# Altium schematic sheet IO_section.SchDoc
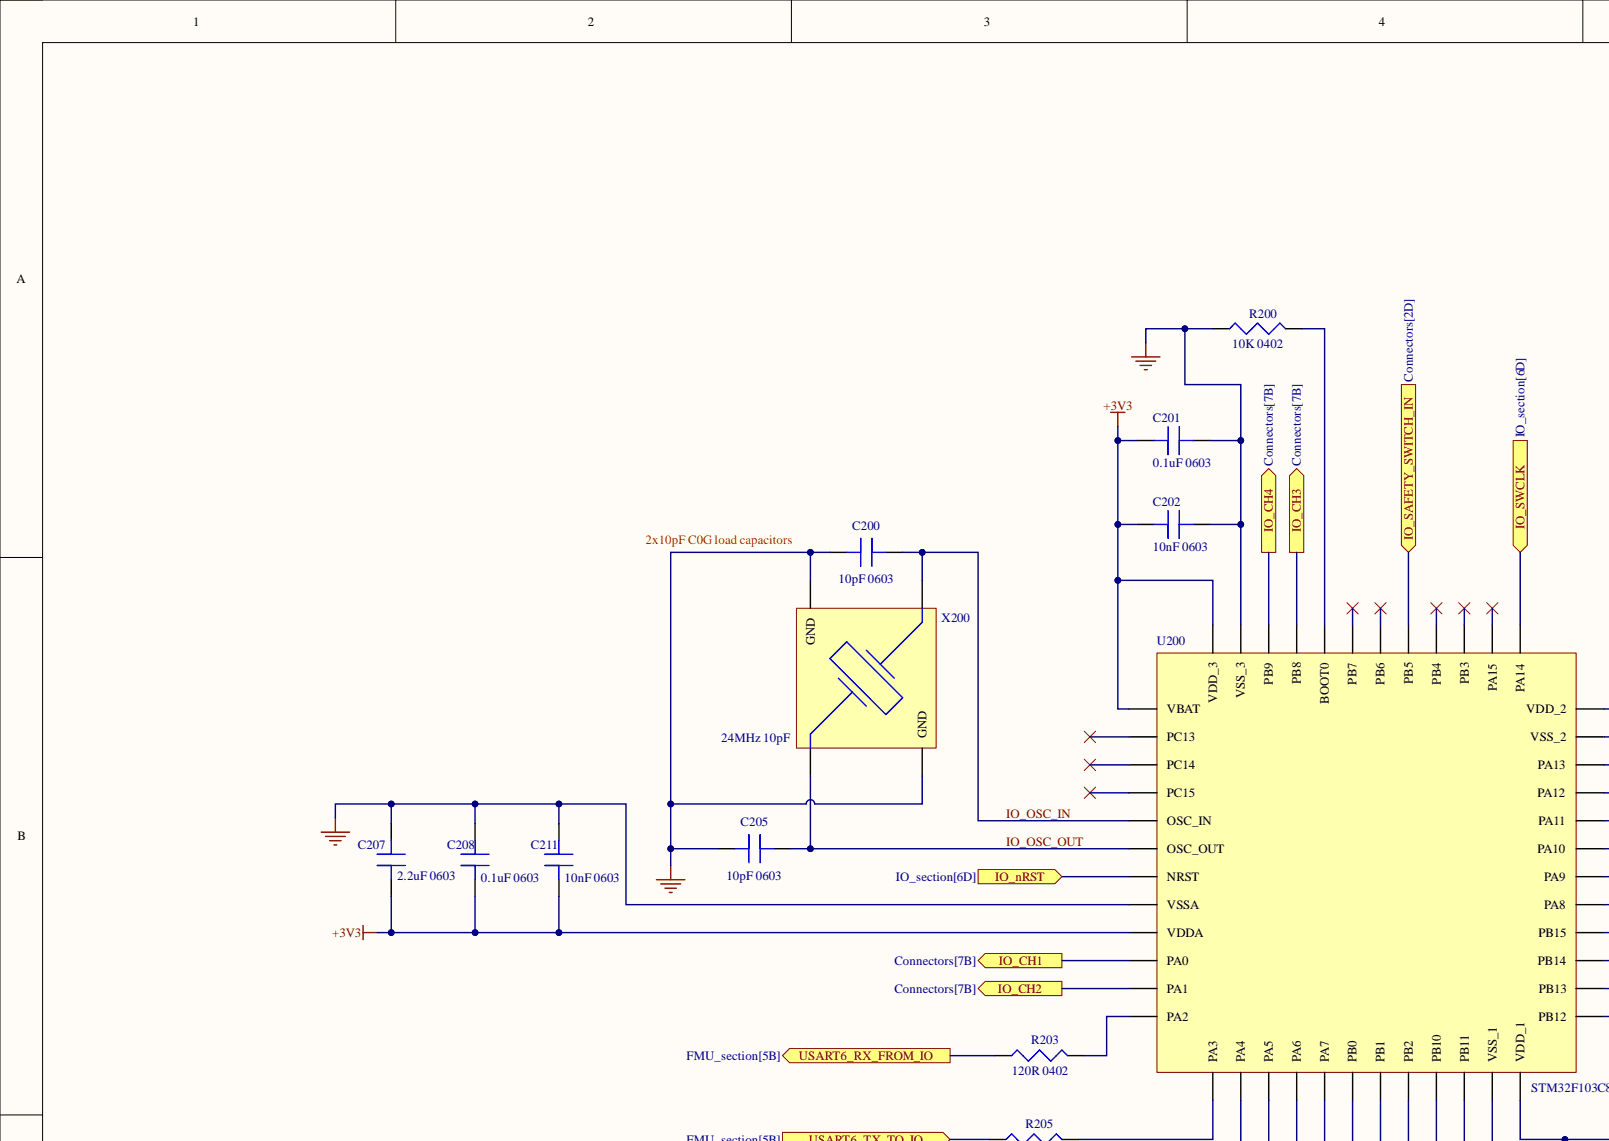
[diagram p0: IO_section.SchDoc, top left]
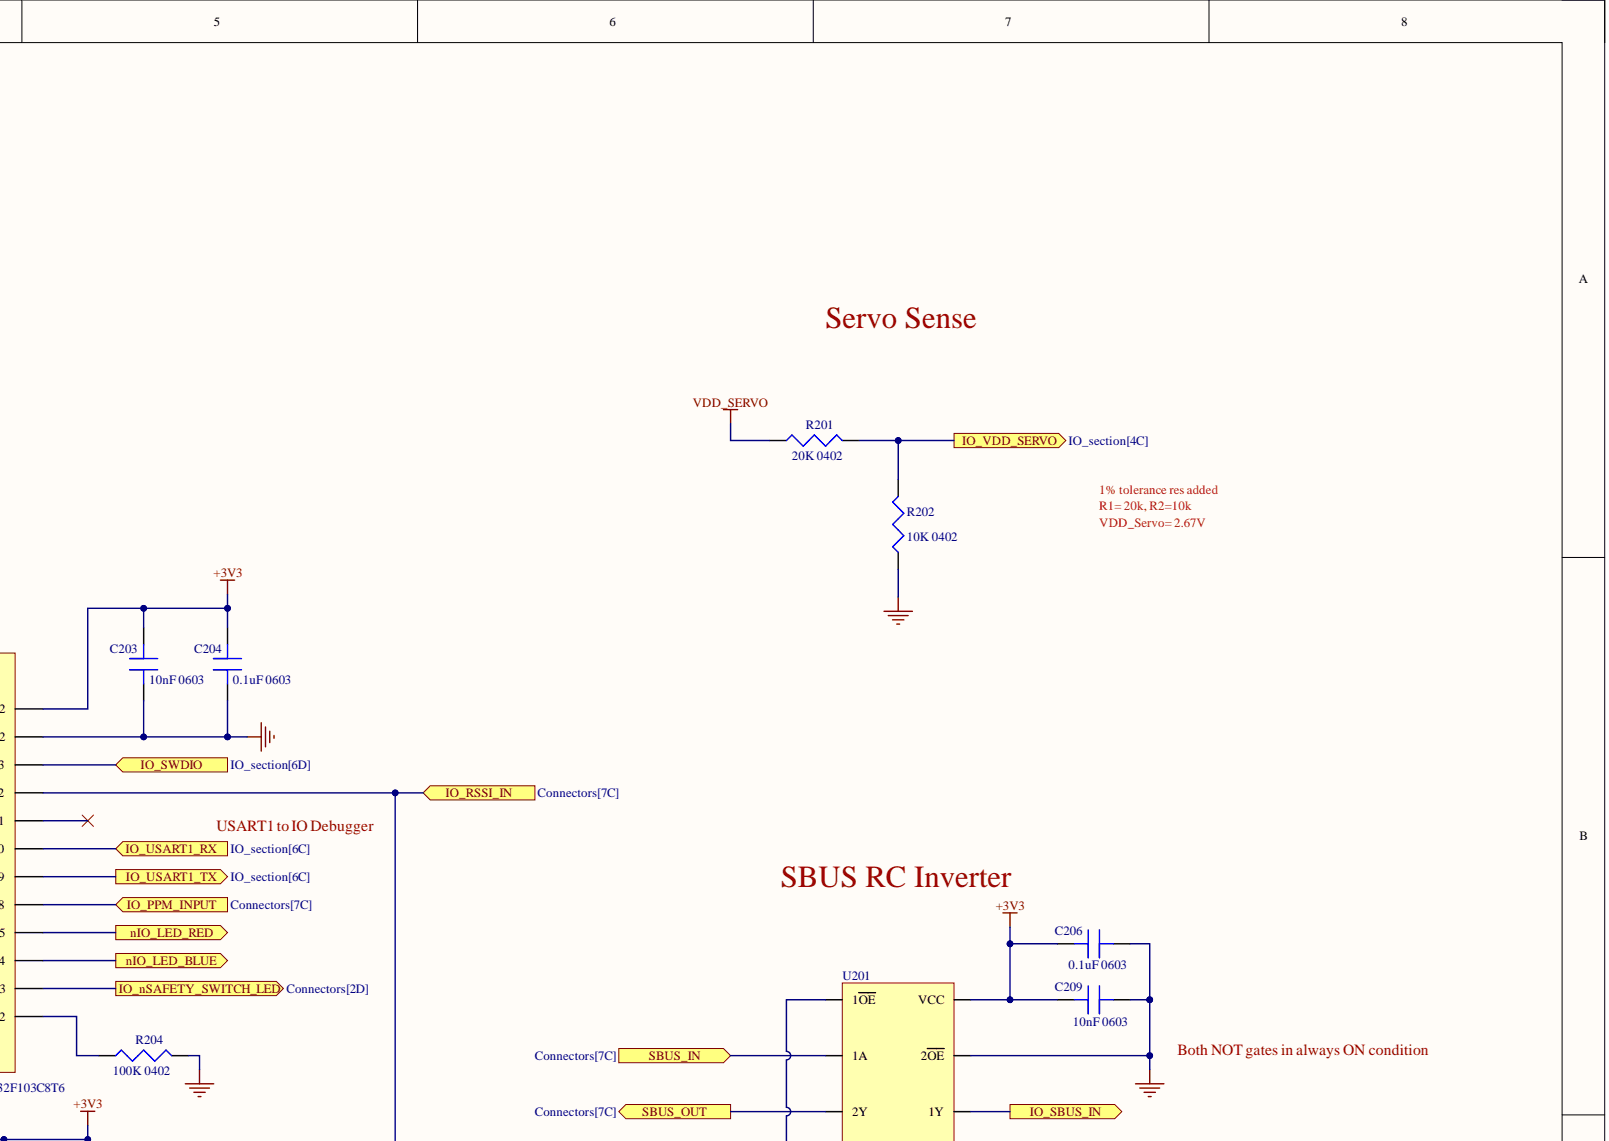
[diagram p1: IO_section.SchDoc, top right]
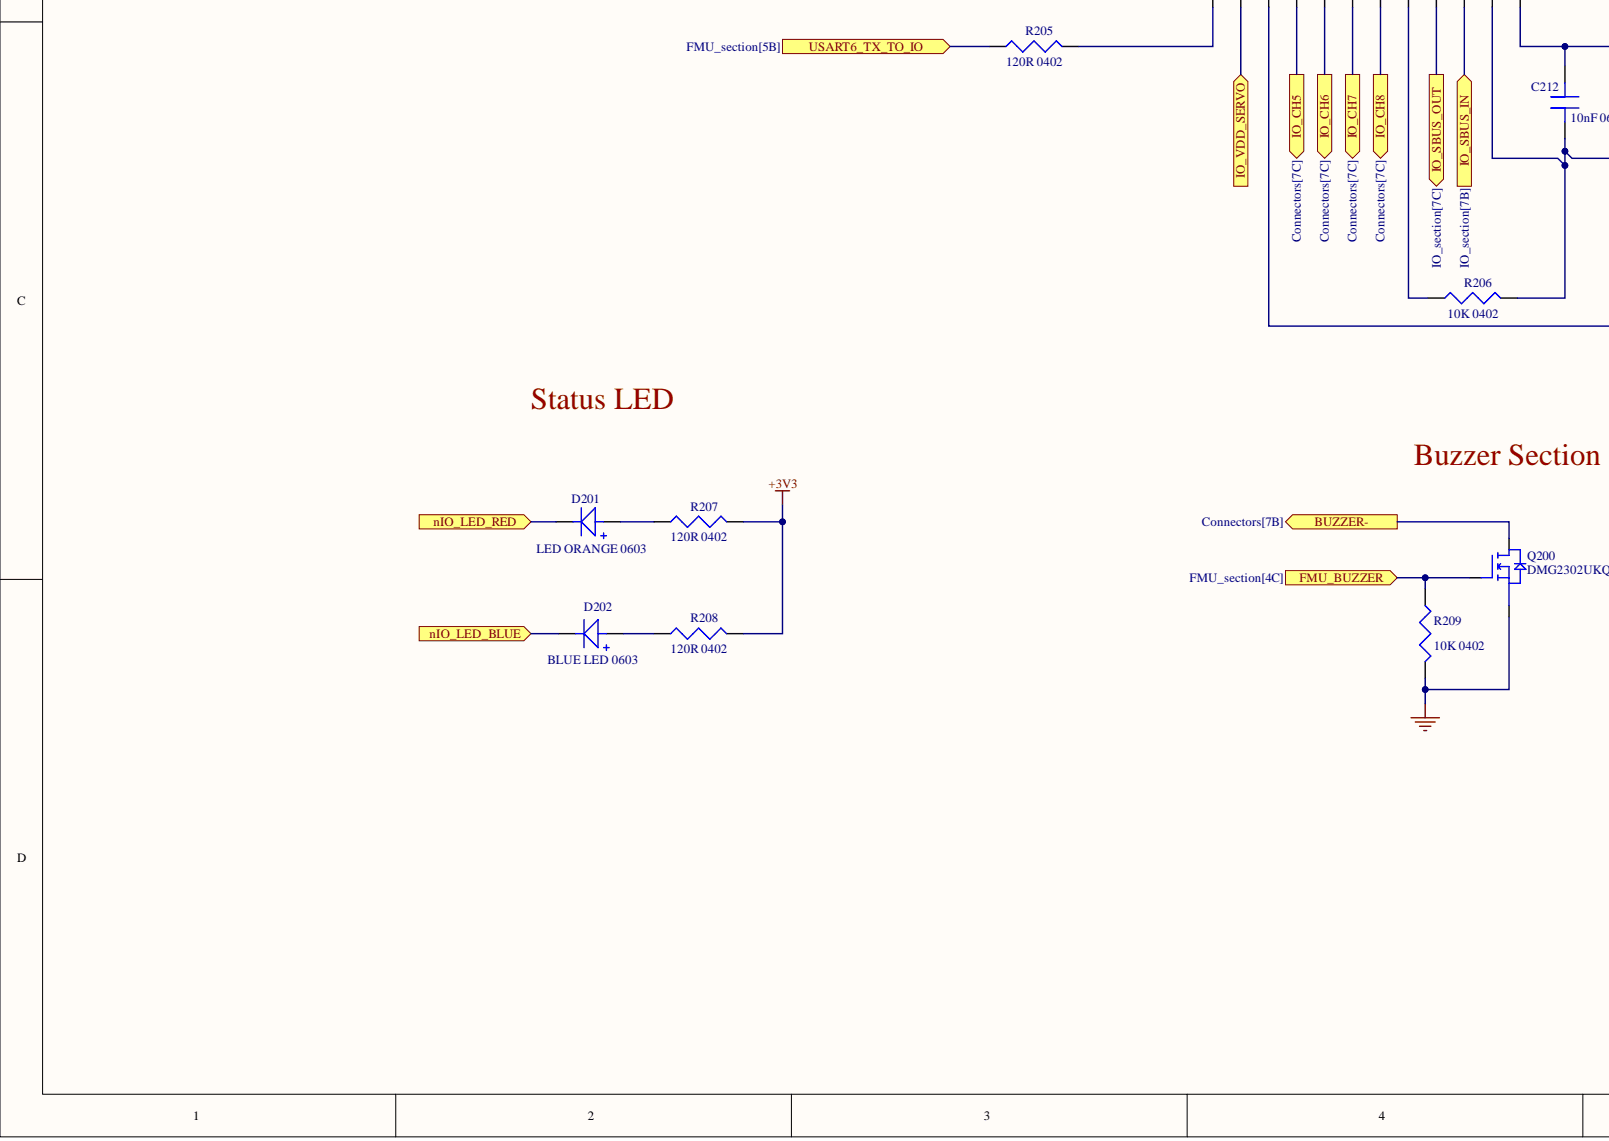
[diagram p2: IO_section.SchDoc, bottom left]
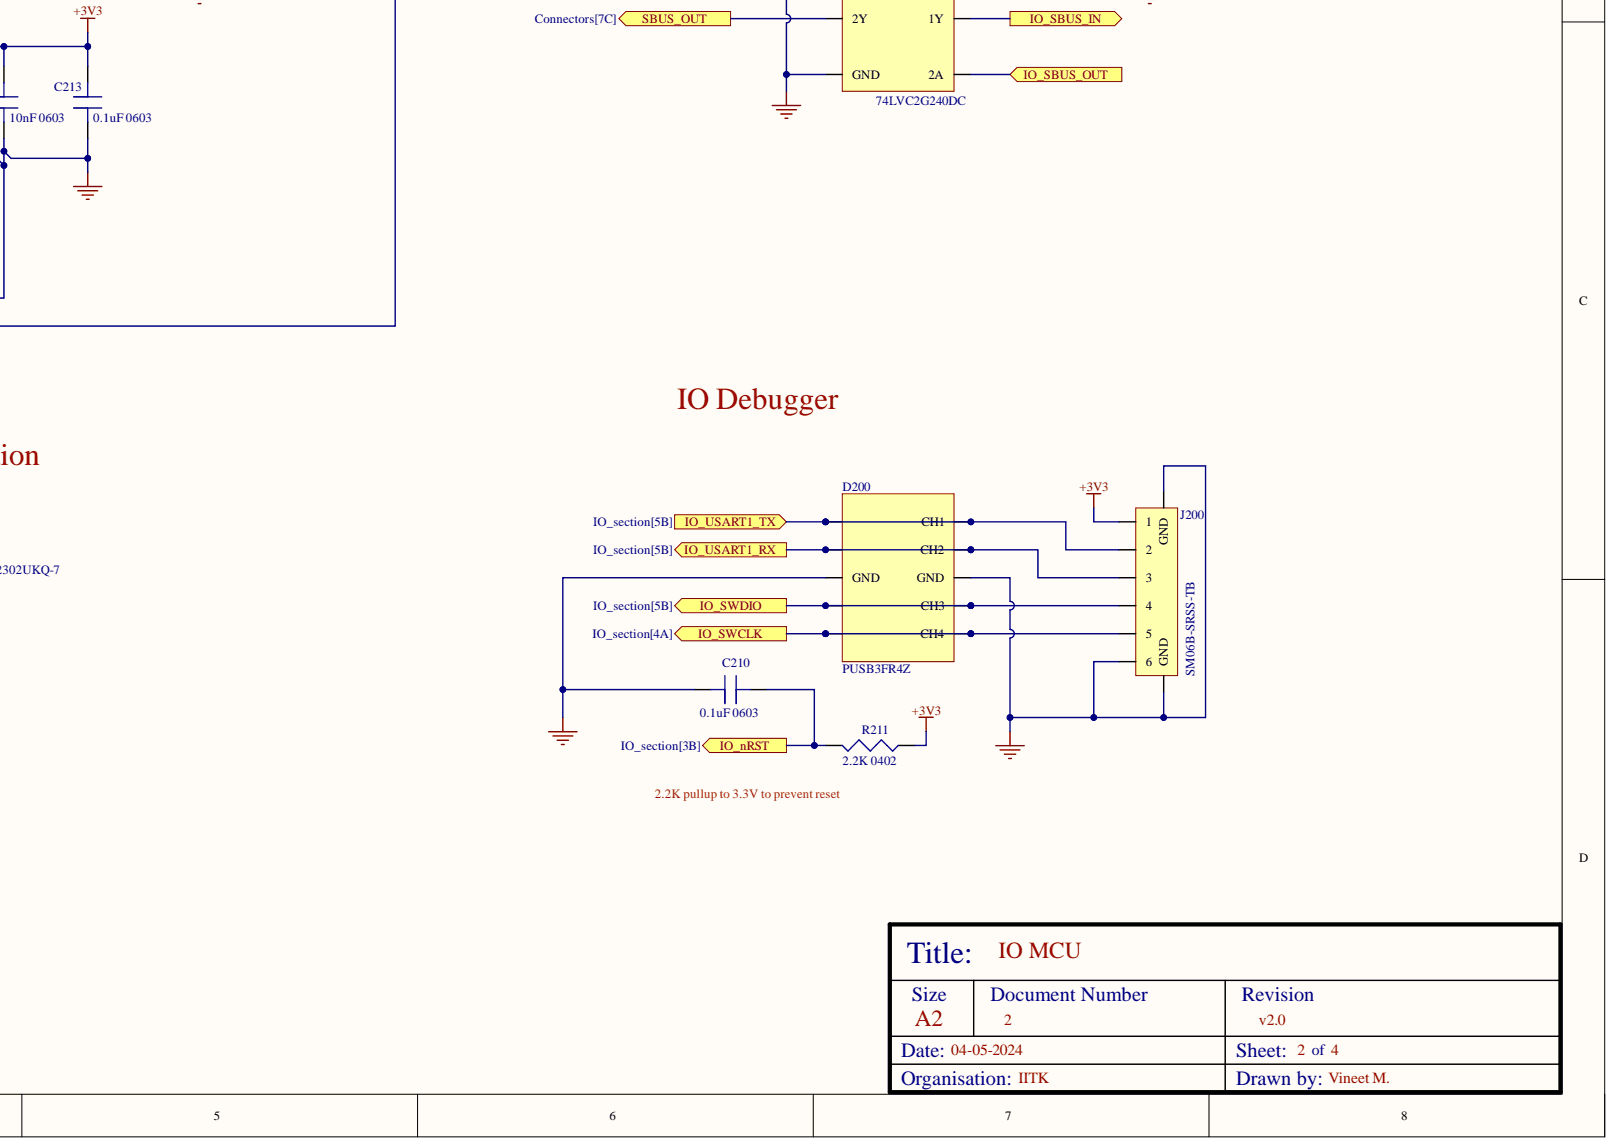
[diagram p3: IO_section.SchDoc, bottom right]

## Parts
C200  10pF 0603  value 10pF  [C0603]
C201  0.1uF 0603  Case Code (Imperial): 0603  [C0603]
C202  10nF 0603  Capacitance: 10nF  Case Code (Imperial): 0603  [C0603]
C203  10nF 0603  Capacitance: 10nF  [C0603]
C204  0.1uF 0603  Case Code (Imperial): 0603  [C0603]
C205  10pF 0603  value 10pF  Case/Package: 0603  [C0603]
C206  0.1uF 0603  Case Code (Imperial): 0603  [C0603]
C207  2.2uF 0603  value 2.2uF  [GRM18]
C208  0.1uF 0603  Case Code (Imperial): 0603  [C0603]
C209  10nF 0603  Capacitance: 10nF  Case Code (Imperial): 0603  [C0603]
C210  0.1uF 0603  Case Code (Imperial): 0603  [C0603]
C211  10nF 0603  Capacitance: 10nF  Case Code (Imperial): 0603  [C0603]
C212  10nF 0603  Capacitance: 10nF  [C0603]
C213  0.1uF 0603  Case Code (Imperial): 0603  [C0603]
D200  PUSB3FR4Z  [PUSB3FR4]
D201  LED ORANGE 0603  Case Code (Imperial): 0603  [LED_0603]
D202  BLUE LED 0603  [LED_0603]
J200  SM06B-SRSS-TB  [SM06BSRSSTBLFSN]
Q200  DMG2302UKQ-7  [DMG2302UKQ]
R200  10K 0402  Case/Package: 0402  [R0402]
R201  20K 0402  Case/Package: 0402  [R0402]
R202  10K 0402  Case/Package: 0402  [R0402]
R203  120R 0402  [R0402]
R204  100K 0402  Case/Package: 0402  [R0402]
R205  120R 0402  [R0402]
R206  10K 0402  Case/Package: 0402  [R0402]
R207  120R 0402  [R0402]
R208  120R 0402  [R0402]
R209  10K 0402  Case/Package: 0402  [R0402]
R211  2.2K 0402  Case Code (Imperial): 0402  [R0402]
U200  STM32F103C8T6  [STM32F103C8T6]
U201  74LVC2G240DC  [74LVC2G240DC]
X200  24MHz 10pF  [ABM8]

## Ports
BUZZER-, FMU_BUZZER, IO_CH1, IO_CH2, IO_CH3, IO_CH4, IO_CH5, IO_CH6, IO_CH7, IO_CH8, IO_nRST, IO_nSAFETY_SWITCH_LED, IO_PPM_INPUT, IO_RSSI_IN, IO_SAFETY_SWITCH_IN, IO_SBUS_IN, IO_SBUS_OUT, IO_SWCLK, IO_SWDIO, IO_USART1_RX, IO_USART1_TX, IO_VDD_SERVO, nIO_LED_BLUE, nIO_LED_RED, SBUS_IN, SBUS_OUT, USART6_RX_FROM_IO, USART6_TX_TO_IO

## Nets
+3V3: C201.1 C202.1 C203.2 C204.2 C206.2 C207.1 C208.2 C209.2 C211.2 C212.2 C213.2 J200.1 R207.2 R208.2 R211.1 U200.1(VBAT) U200.9(VDDA) U200.24(VDD_1) U200.36(VDD_2) U200.48(VDD_3) U201.8(VCC)
GND: C200.1 C201.2 C202.2 C203.1 C204.1 C205.1 C206.1 C207.2 C208.1 C209.1 C210.2 C211.1 C212.1 C213.1 D200.3(GND) D200.8(GND) J200.6 J200.7(GND) Q200.3 R200.1 R202.1 R204.2 R206.2 R209.2 U200.8(VSSA) U200.23(VSS_1) U200.35(VSS_2) U200.47(VSS_3) U201.1(1OE) U201.4(GND) U201.7(2OE) X200.2(GND) X200.4(GND)
VDD_SERVO: R201.1
BUZZER-: Q200.2 ; port BUZZER-
FMU_BUZZER: Q200.1 R209.1 ; port FMU_BUZZER
IO_CH1: U200.10(PA0) ; port IO_CH1
IO_CH2: U200.11(PA1) ; port IO_CH2
IO_CH3: U200.45(PB8) ; port IO_CH3
IO_CH4: U200.46(PB9) ; port IO_CH4
IO_CH5: U200.16(PA6) ; port IO_CH5
IO_CH6: U200.17(PA7) ; port IO_CH6
IO_CH7: U200.18(PB0) ; port IO_CH7
IO_CH8: U200.19(PB1) ; port IO_CH8
IO_nRST: C210.1 R211.2 U200.7(NRST) ; port IO_nRST
IO_nSAFETY_SWITCH_LED: U200.26(PB13) ; port IO_nSAFETY_SWITCH_LED
IO_OSC_IN: C200.2 U200.5(OSC_IN) X200.3
IO_OSC_OUT: C205.2 U200.6(OSC_OUT) X200.1
IO_PPM_INPUT: U200.29(PA8) ; port IO_PPM_INPUT
IO_RSSI_IN: U200.15(PA5) U200.33(PA12) ; port IO_RSSI_IN
IO_SAFETY_SWITCH_IN: U200.41(PB5) ; port IO_SAFETY_SWITCH_IN
IO_SBUS_IN: U200.22(PB11) U201.6(1Y) ; port IO_SBUS_IN
IO_SBUS_OUT: U200.21(PB10) U201.5(2A) ; port IO_SBUS_OUT
IO_SWCLK: D200.5(CH4) D200.6 J200.5 U200.37(PA14) ; port IO_SWCLK
IO_SWDIO: D200.4(CH3) D200.7 J200.4 U200.34(PA13) ; port IO_SWDIO
IO_USART1_RX: D200.2(CH2) D200.9 J200.3 U200.31(PA10) ; port IO_USART1_RX
IO_USART1_TX: D200.1(CH1) D200.10 J200.2 U200.30(PA9) ; port IO_USART1_TX
IO_VDD_SERVO: R201.2 R202.2 U200.14(PA4) ; port IO_VDD_SERVO
nIO_LED_BLUE: D202.2 U200.27(PB14) ; port nIO_LED_BLUE
nIO_LED_RED: D201.2 U200.28(PB15) ; port nIO_LED_RED
SBUS_IN: U201.2(1A) ; port SBUS_IN
SBUS_OUT: U201.3(2Y) ; port SBUS_OUT
USART6_RX_FROM_IO: R203.1 ; port USART6_RX_FROM_IO
USART6_TX_TO_IO: R205.1 ; port USART6_TX_TO_IO
NetD201_1: D201.1 R207.1
NetD202_1: D202.1 R208.1
NetR200_2: R200.2 U200.44(BOOT0)
NetR203_2: R203.2 U200.12(PA2)
NetR204_1: R204.1 U200.25(PB12)
NetR205_2: R205.2 U200.13(PA3)
NetR206_1: R206.1 U200.20(PB2)

Not connected: U200 2(PC13) 3(PC14) 4(PC15) 32(PA11) 38(PA15) 39(PB3) 40(PB4) 42(PB6) 43(PB7)
